# Altium schematic sheet UserInputs.SchDoc(UserInputs)
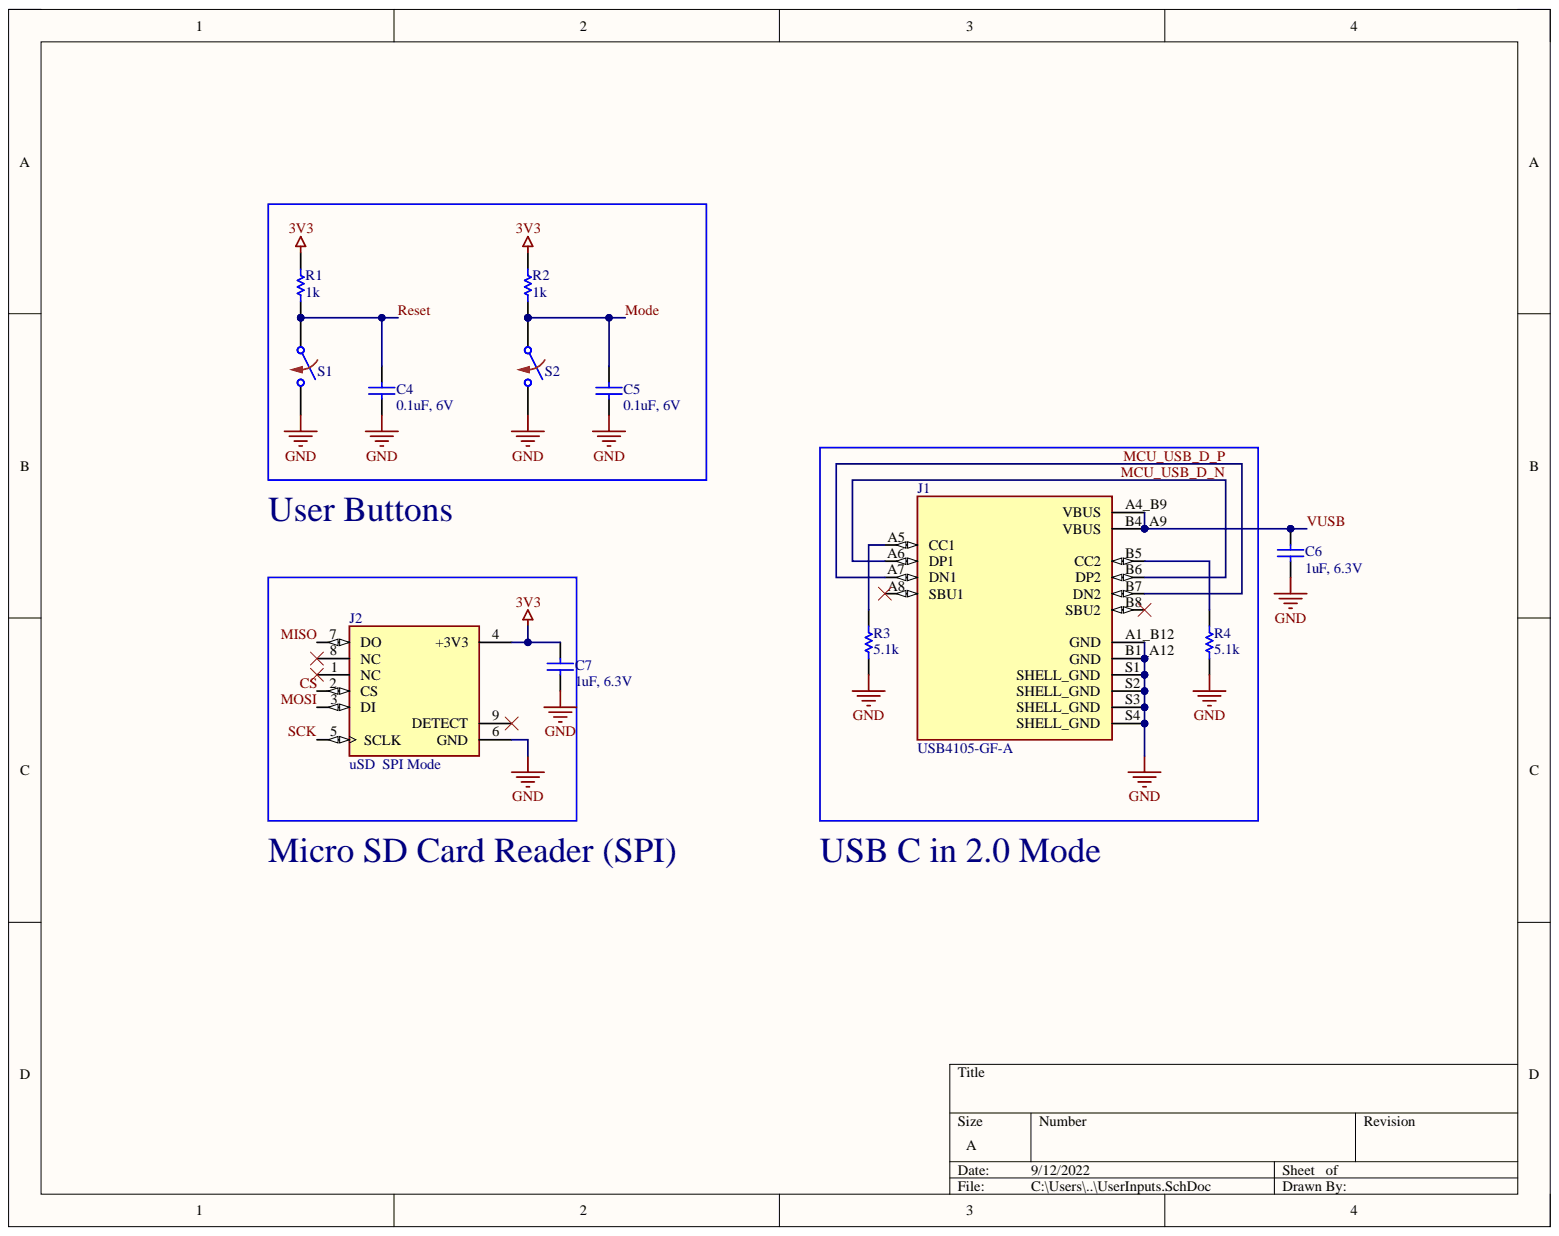
[diagram p0: UserInputs.SchDoc]

## Parts
C4  value 0.1uF, 6V  [ANTC1608X90N]  (hidden comment: Capacitor)
C5  value 0.1uF, 6V  [ANTC1608X90N]  (hidden comment: Capacitor)
C6  value 1uF, 6.3V  [ANTC1608X90N]  (hidden comment: Capacitor)
C7  value 1uF, 6.3V  [ANTC1608X90N]  (hidden comment: Capacitor)
J1  USB4105-GF-A  [GCT_USB4105-GF-A]
J2  uSD  SPI Mode  [GCT_MEM2051-00-195-00-A]
R1  value 1k  [ANTC1608X90N]  (hidden comment: Resistor)
R2  value 1k  [ANTC1608X90N]  (hidden comment: Resistor)
R3  value 5.1k  [ANTC1608X90N]  (hidden comment: Resistor)
R4  value 5.1k  [ANTC1608X90N]  (hidden comment: Resistor)
S1  Switch Normally Opened  [TL3313AF250QG]  (value not drawn)
S2  Switch Normally Opened  [TL3313AF250QG]  (value not drawn)

## Nets
3V3: C7.2 J2.4(+3V3) R1.2 R2.2
GND: C4.1 C5.1 C6.1 C7.1 J1.A1_B12(GND) J1.B1_A12(GND) J1.S1(SHELL_GND) J1.S2(SHELL_GND) J1.S3(SHELL_GND) J1.S4(SHELL_GND) J2.6(GND) R3.1 R4.1 S1.2 S2.2
CS: J2.2(CS)
MCU_USB_D_N: J1.A6(DP1) J1.B6(DP2)
MCU_USB_D_P: J1.A7(DN1) J1.B7(DN2)
MISO: J2.7(DO)
Mode: C5.2 R2.1 S2.1
MOSI: J2.3(DI)
Reset: C4.2 R1.1 S1.1
SCK: J2.5(SCLK)
VUSB: C6.2 J1.A4_B9(VBUS) J1.B4_A9(VBUS)
NetJ1_A5: J1.A5(CC1) R3.2
NetJ1_B5: J1.B5(CC2) R4.2

Not connected: J1 A8(SBU1) B8(SBU2); J2 1(NC) 8(NC) 9(DETECT)

## Library data of the parts
C4-C7 = Capacitor: SimulationGenericComponent: True; Component Kind: Standard; Description: Capacitor; Library Name: Simulation Generic Components.IntLib; Simulation: C_Capacitor_Default
J1 = USB4105-GF-A: USB-C (USB TYPE-C) USB 2.0 Receptacle Connector 24 (16+8 Dummy) Position Surface Mount, Right Angle; Through Hole; Availability: Unavailable; Component Kind: Standard; Library Name: USB4105-GF-A.SchLib; MP: USB4105-GF-A; Package: None; Price: None
J2 = MEM2051-00-195-00-A: 9 (8 + 1) Position Card Connector microSDâ„¢ Surface Mount, Right Angle Gold, 1.95mm profile, Normally open switch, Push push, Top mount; MF: Global Connector Technology; Availability: Warning; Check_prices: https://www.snapeda.com/parts/MEM2051-00-195-00-A/Global%20Connector%20Technology/view-part/779220/?ref=eda; Component Kind: Standard; Library Name: MEM2051-00-195-00-A.SchLib; MP: MEM2051-00-195-00-A; Package: None; Price: None; Purchase-URL: https://pricing.snapeda.com/search/part/MEM2051-00-195-00-A/?ref=eda; SnapEDA_Link: https://www.snapeda.com/parts/MEM2051-00-195-00-A/Global%20Connector%20Technology/view-part/779220/?ref=snap
R1-R4 = Resistor: SimulationGenericComponent: True; TC1: 0; Component Kind: Standard; Description: Resistor; Library Name: Simulation Generic Components.IntLib; Simulation: R_Resistor_Default; TC2: 0
S1 S2 = SW NO: closed: 0; Rclosed: 1m; Ropen: 1Meg; SimulationGenericComponent: True; Component Kind: Standard; Description: Switch Normally Opened; Library Name: Simulation Generic Components.IntLib; Simulation: SPST_SWITCH
